# Altium schematic sheet CAN-SW.SchDoc(CAN-SW)
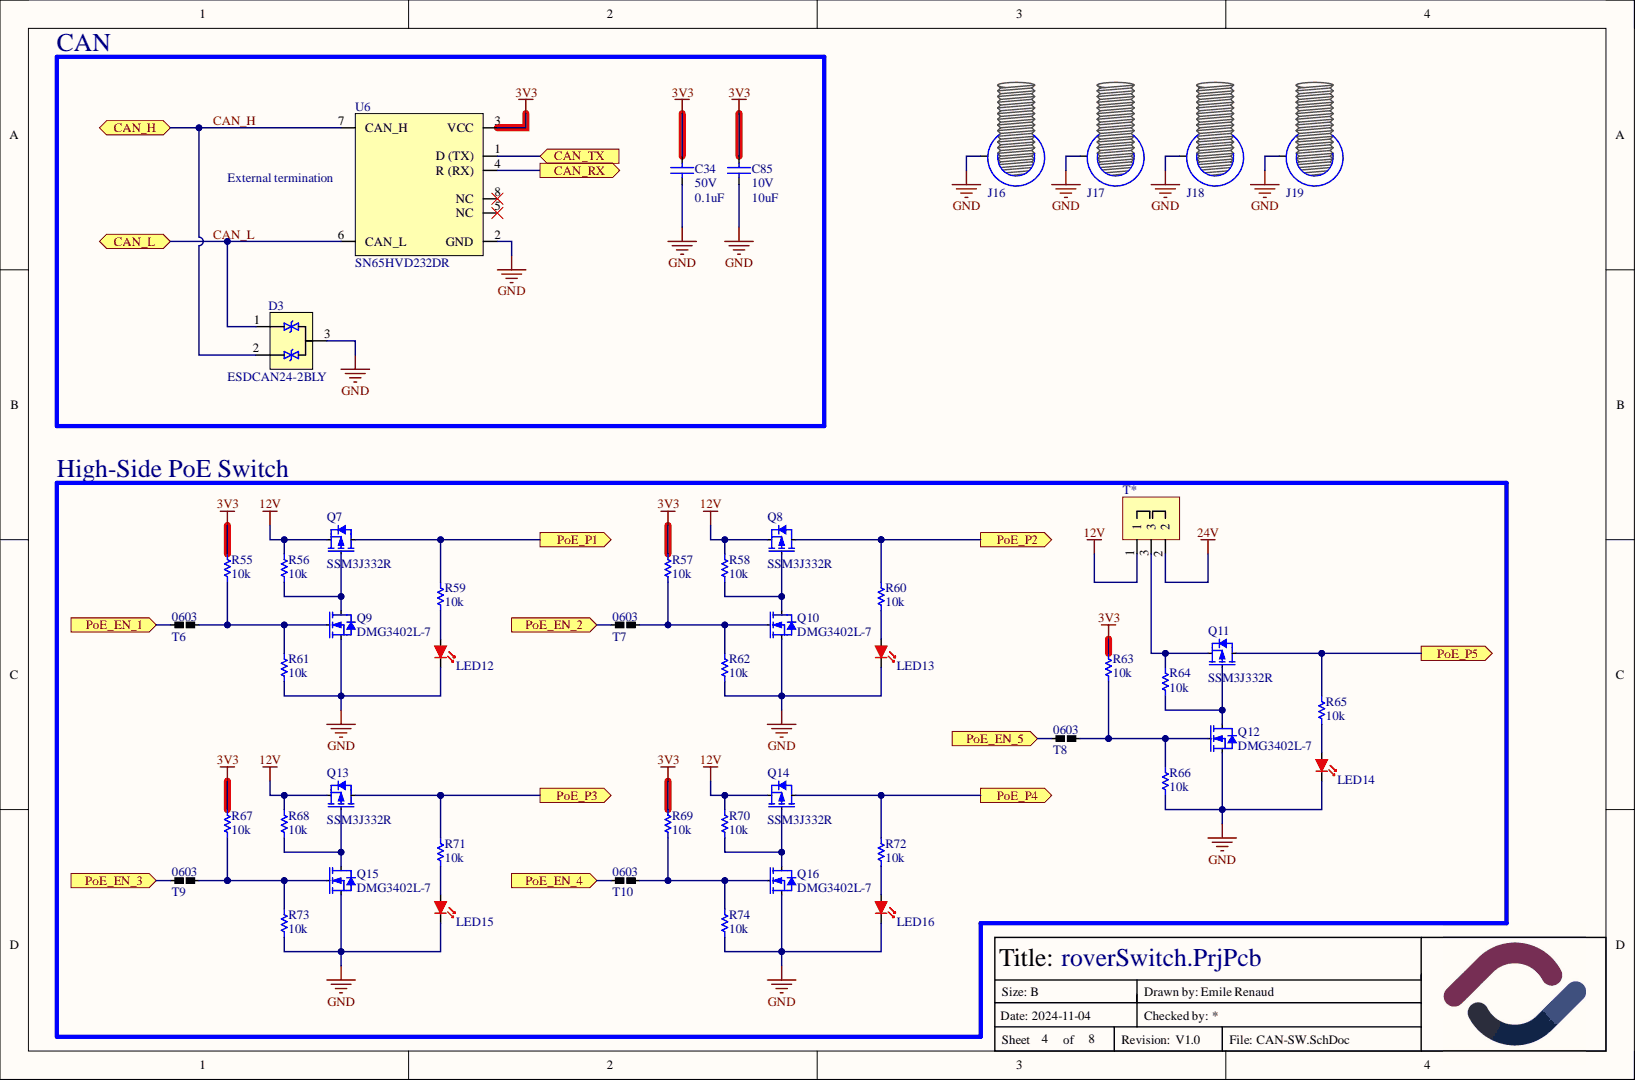
[diagram p0: CAN-SW.SchDoc]

## Parts
C34  value 0.1uF  Volt: 50V  [CAPACITOR-0603]  (hidden comment: Capacitor-0603-0.1uF-50V-X7R)
C85  value 10uF  Volt: 10V  [CAPACITOR-0603]  (hidden comment: Capacitor-0603-10uF-10V-X5R)
D3  ESDCAN24-2BLY  [SOT-23-3]
J16  hole  [HOLE-3.40MM-M3-8MMEYELET]  (value not drawn)  (no BOM)
J17  hole  [HOLE-3.40MM-M3-8MMEYELET]  (value not drawn)  (no BOM)
J18  hole  [HOLE-3.40MM-M3-8MMEYELET]  (value not drawn)  (no BOM)
J19  hole  [HOLE-3.40MM-M3-8MMEYELET]  (value not drawn)  (no BOM)
LED12  B1911USD-20D000114U1930  [LED-0603-2PIN]  (value not drawn)
LED13  B1911USD-20D000114U1930  [LED-0603-2PIN]  (value not drawn)
LED14  B1911USD-20D000114U1930  [LED-0603-2PIN]  (value not drawn)
LED15  B1911USD-20D000114U1930  [LED-0603-2PIN]  (value not drawn)
LED16  B1911USD-20D000114U1930  [LED-0603-2PIN]  (value not drawn)
Q7  SSM3J332R  [SOT-23-3]
Q8  SSM3J332R  [SOT-23-3]
Q9  DMG3402L-7  [SOT-23-3]
Q10  DMG3402L-7  [SOT-23-3]
Q11  SSM3J332R  [SOT-23-3]
Q12  DMG3402L-7  [SOT-23-3]
Q13  SSM3J332R  [SOT-23-3]
Q14  SSM3J332R  [SOT-23-3]
Q15  DMG3402L-7  [SOT-23-3]
Q16  DMG3402L-7  [SOT-23-3]
R55  value 10k  [Resistor-0603]  (hidden comment: SMT resistor)
R56  value 10k  [Resistor-0603]  (hidden comment: SMT resistor)
R57  value 10k  [Resistor-0603]  (hidden comment: SMT resistor)
R58  value 10k  [Resistor-0603]  (hidden comment: SMT resistor)
R59  value 10k  [Resistor-0603]  (hidden comment: SMT resistor)
R60  value 10k  [Resistor-0603]  (hidden comment: SMT resistor)
R61  value 10k  [Resistor-0603]  (hidden comment: SMT resistor)
R62  value 10k  [Resistor-0603]  (hidden comment: SMT resistor)
R63  value 10k  [Resistor-0603]  (hidden comment: SMT resistor)
R64  value 10k  [Resistor-0603]  (hidden comment: SMT resistor)
R65  value 10k  [Resistor-0603]  (hidden comment: SMT resistor)
R66  value 10k  [Resistor-0603]  (hidden comment: SMT resistor)
R67  value 10k  [Resistor-0603]  (hidden comment: SMT resistor)
R68  value 10k  [Resistor-0603]  (hidden comment: SMT resistor)
R69  value 10k  [Resistor-0603]  (hidden comment: SMT resistor)
R70  value 10k  [Resistor-0603]  (hidden comment: SMT resistor)
R71  value 10k  [Resistor-0603]  (hidden comment: SMT resistor)
R72  value 10k  [Resistor-0603]  (hidden comment: SMT resistor)
R73  value 10k  [Resistor-0603]  (hidden comment: SMT resistor)
R74  value 10k  [Resistor-0603]  (hidden comment: SMT resistor)
T6  NetTie_0603_Short  [NetTie-0603-short]  (value not drawn)
T7  NetTie_0603_Short  [NetTie-0603-short]  (value not drawn)
T8  NetTie_0603_Short  [NetTie-0603-short]  (value not drawn)
T9  NetTie_0603_Short  [NetTie-0603-short]  (value not drawn)
T10  NetTie_0603_Short  [NetTie-0603-short]  (value not drawn)
T*  Jumper-3pos-small  [Jumper-3pos-small]  (value not drawn)
U6  SN65HVD232DR  [SOIC-8]

## Ports
CAN_H, CAN_L, CAN_RX, CAN_TX, PoE_EN_1, PoE_EN_2, PoE_EN_3, PoE_EN_4, PoE_EN_5, PoE_P1, PoE_P2, PoE_P3, PoE_P4, PoE_P5

## Nets
3V3: C34.2 C85.2 R55.2 R57.2 R63.2 R67.2 R69.2 U6.3(VCC)
12V: Q7.2 Q8.2 Q13.2 Q14.2 R56.2 R58.2 R68.2 R70.2 T*.1
24V: T*.2
GND: C34.1 C85.1 D3.3 J16.1 J17.1 J18.1 J19.1 LED12.1 LED13.1 LED14.1 LED15.1 LED16.1 Q9.2 Q10.2 Q12.2 Q15.2 Q16.2 R61.1 R62.1 R66.1 R73.1 R74.1 U6.2(GND)
CAN_H: D3.2 U6.7(CAN_H) ; port CAN_H
CAN_L: D3.1 U6.6(CAN_L) ; port CAN_L
CAN_RX: U6.4(R_(RX)) ; port CAN_RX
CAN_TX: U6.1(D_(TX)) ; port CAN_TX
PoE_EN_1: T6.2 ; port PoE_EN_1
PoE_EN_2: T7.2 ; port PoE_EN_2
PoE_EN_3: T9.2 ; port PoE_EN_3
PoE_EN_4: T10.2 ; port PoE_EN_4
PoE_EN_5: T8.2 ; port PoE_EN_5
PoE_P1: Q7.3 R59.2 ; port PoE_P1
PoE_P2: Q8.3 R60.2 ; port PoE_P2
PoE_P3: Q13.3 R71.2 ; port PoE_P3
PoE_P4: Q14.3 R72.2 ; port PoE_P4
PoE_P5: Q11.3 R65.2 ; port PoE_P5
NetLED12_2: LED12.2 R59.1
NetLED13_2: LED13.2 R60.1
NetLED14_2: LED14.2 R65.1
NetLED15_2: LED15.2 R71.1
NetLED16_2: LED16.2 R72.1
NetQ7_1: Q7.1 Q9.3 R56.1
NetQ8_1: Q8.1 Q10.3 R58.1
NetQ9_1: Q9.1 R55.1 R61.2 T6.1
NetQ10_1: Q10.1 R57.1 R62.2 T7.1
NetQ11_1: Q11.1 Q12.3 R64.1
NetQ11_2: Q11.2 R64.2 T*.3
NetQ12_1: Q12.1 R63.1 R66.2 T8.1
NetQ13_1: Q13.1 Q15.3 R68.1
NetQ14_1: Q14.1 Q16.3 R70.1
NetQ15_1: Q15.1 R67.1 R73.2 T9.1
NetQ16_1: Q16.1 R69.1 R74.2 T10.1

Not connected: U6 5(NC) 8(NC)

## Library data of the parts
C34 = Capacitor-0603-0.1uF-50V-X7R: Precision: X7R-10%; Component Kind: Standard; Description: Capacitor-0603-0.1uF-50V-X7R; Library Name: Capacitors.SchLib; Mounted: Yes; Package / Case: Metric 1608, EIA 0603; Supplier 1: Digi-Key; Supplier 2: Digi-Key; Supplier Part Number 1: 1276-1935-2-ND; Supplier Part Number 2: 1276-1934-2-ND
C85 = Capacitor-0603-10uF-10V-X5R: 10 µF ±20% 10V Ceramic Capacitor X5R 0603 (1608 Metric); Component Kind: Standard; Library Name: Capacitors.SchLib; Mounted: Yes; Package / Case: Metric 1608 , EIA 0603; Precision: 20%; Supplier 1: Digikey; Supplier Part Number 1: 4713-GMC10X5R106M10NTCT-ND
D3 = ESDCAN24-2BLY: 55V Clamp 6A (8/20µs) Ipp Tvs Diode Surface Mount SOT-23; Volt: 24; Component Kind: Standard; Library Name: diodes-tvs.SchLib; Mounted: Yes; Package / Case: SOT-23-3; Supplier 1: Digi-Key; Supplier Part Number 1: 5272-ESDCAN24-2BLYCT-ND
J16-J19 = Hole 3.40mm M3-Eyelet: Hole for M3 Bolt with 8mm pad; Library Name: JumpersAndHoles.SchLib; Mounted: N/A
LED12-LED16 = B1911USD-20D000114U1930: LED RED CLEAR 0603 SMD; Component Kind: Standard; Library Name: LEDs.SchLib; Mounted: Yes; Package / Case: 0603 (1608 Metric); Supplier 1: Digi-Key; Supplier Part Number 1: 3147-B1911USD-20D000114U1930CT-ND
Q7 Q8 Q11 Q13 Q14 = SSM3J332R: P-Channel 30 V 6A (Ta) 1W (Ta) Surface Mount SOT-23F; Volt: 30V; Component Kind: Standard; Current: 6A; Library Name: FET-P-Small.SchLib; Mounted: Yes; Package / Case: SOT-523; Supplier 1: Digi-Key; Supplier Part Number 1: SSM3J332RLFCT-ND
Q9 Q10 Q12 Q15 Q16 = DMG3402L-7: N-Channel 30 V 4A (Ta) 1.4W (Ta) Surface Mount SOT-23-3; Volt: 30V; Component Kind: Standard; Current: 4A; Library Name: FET-N-Small.SchLib; Mounted: Yes; Package / Case: SOT-23-3; Supplier 1: Digi-Key; Supplier Part Number 1: DMG3402L-7DICT-ND
R55-R74 = Resistor-0603-10k: Resistor0603-10k; Precision: 1%; Watt: 0.1W; Component Kind: Standard; Library Name: Resistors.SchLib; Mounted: Yes; Package / Case: Metric 1608, EIA 0603; Supplier 1: Digi-Key; Supplier Part Number 1: 311-10.0KHRTR-ND
U6 = SN65HVD232DR: 1/1 Transceiver Half CANbus 8-SOIC; Component Kind: Standard; HelpURL: https://www.ti.com/lit/ds/symlink/sn65hvd230.pdf?HQS=dis-dk-null-digikeymode-dsf-pf-null-wwe&ts=1723930967656&ref_url=https%253A%252F%252Fwww.ti.com%252Fgeneral%252Fdocs%252Fsuppproductinfo.tsp%253FdistId%253D10%2526gotoUrl%253Dhttps%253A%252F%252Fwww.ti.com%252Flit%252Fgpn%252Fsn65hvd230; Library Name: Transceiver.SchLib; Mounted: Yes; Package / Case: 8-SOIC; Supplier 1: Digikey; Supplier Part Number 1: 296-26344-1-ND
T6-T10 = NetTie_0603_Short: Component Kind: Standard; Library Name: JumpersAndHoles.SchLib
T* = Jumper-3pos-small: Component Kind: Standard; Library Name: JumpersAndHoles.SchLib
